# Altium schematic sheet ethernet.SchDoc(ethernet)
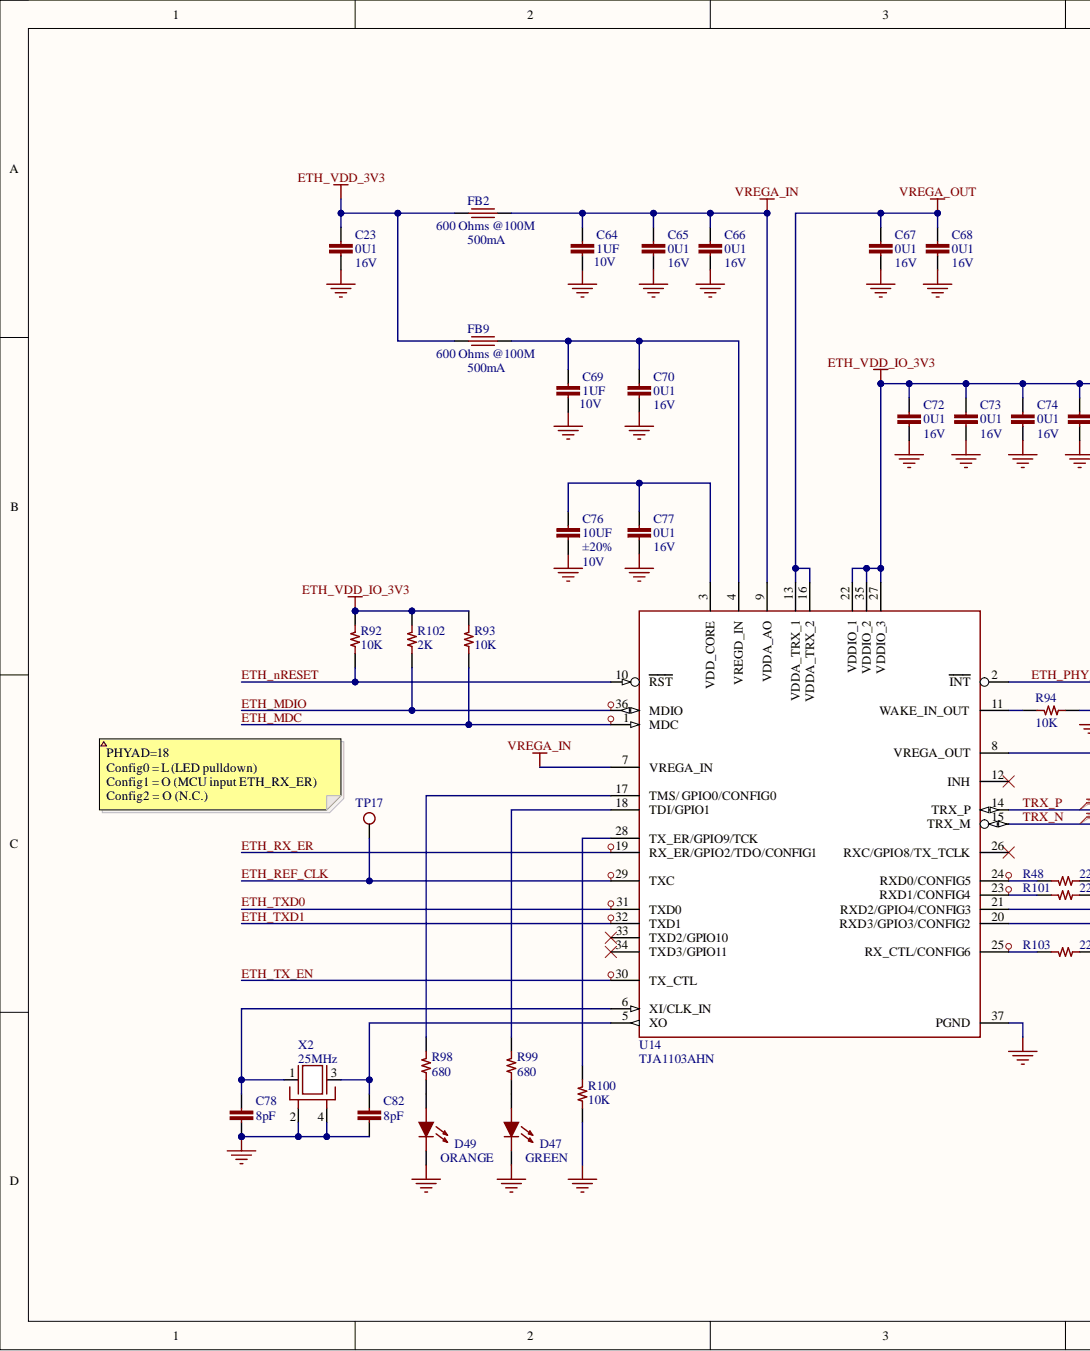
[diagram p0: ethernet.SchDoc, left]
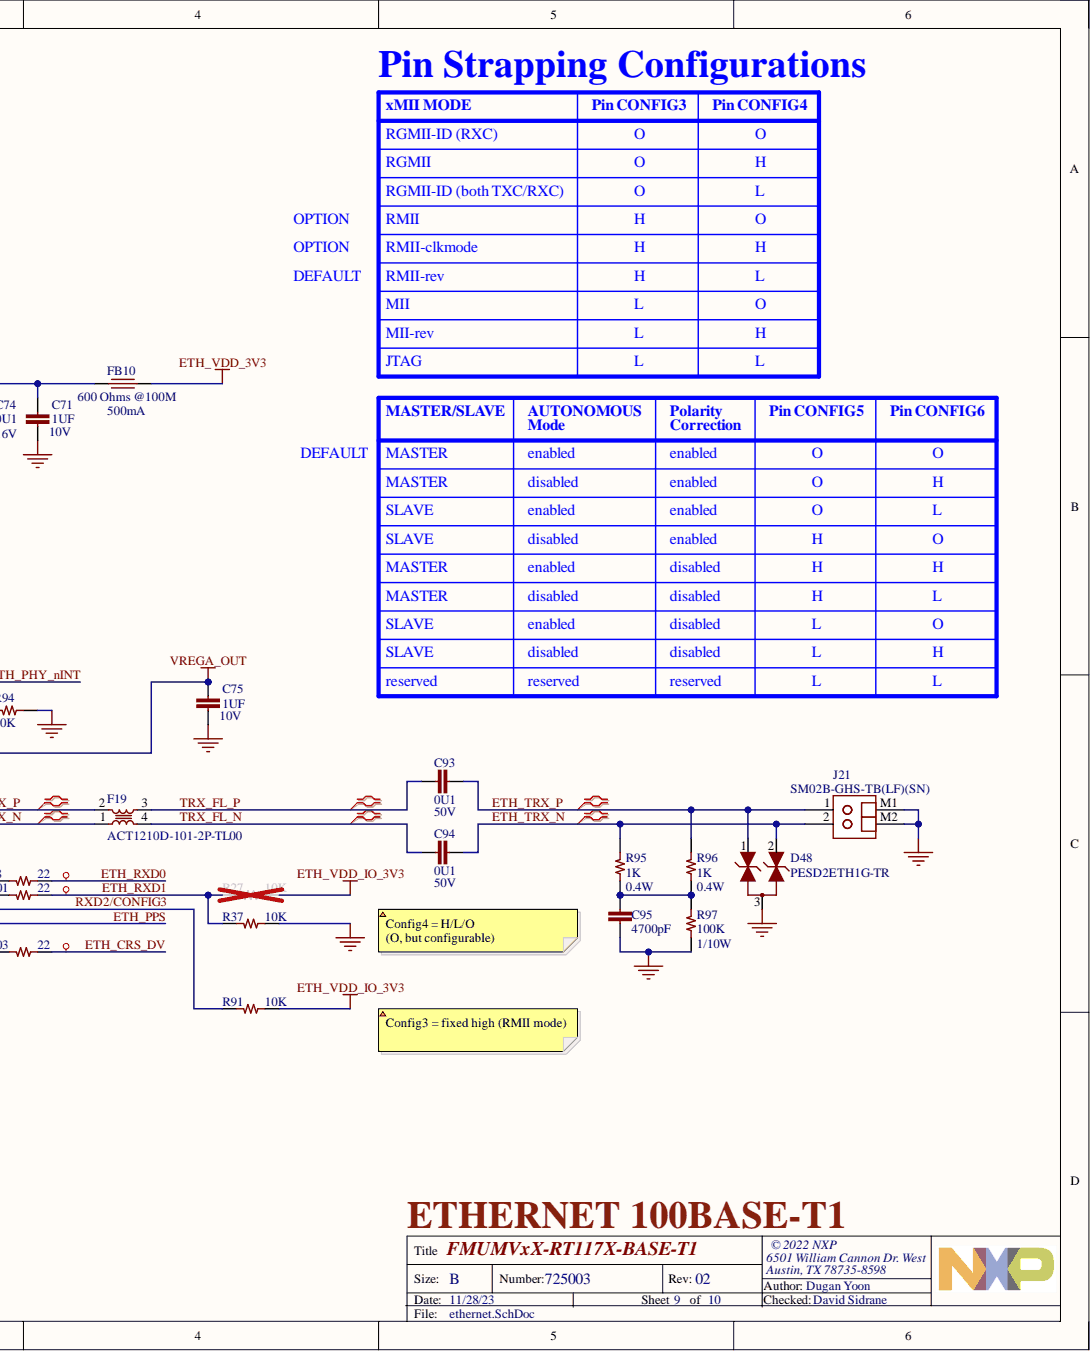
[diagram p1: ethernet.SchDoc, right]

## Parts
C23  value 0U1  Voltage - Rated: 16V  [CAPC100X50X56L25N]  (hidden comment: CAP CER 0.1UF 10% 16V X7R 0402)
C64  value 1UF  Voltage - Rated: 10V  [CAPC100X50X56L25N]  (hidden comment: CAP CER 1UF 20% 10V X5R 0402)
C65  value 0U1  Voltage - Rated: 16V  [CAPC100X50X56L25N]  (hidden comment: CAP CER 0.1UF 10% 16V X7R 0402)
C66  value 0U1  Voltage - Rated: 16V  [CAPC100X50X56L25N]  (hidden comment: CAP CER 0.1UF 10% 16V X7R 0402)
C67  value 0U1  Voltage - Rated: 16V  [CAPC100X50X56L25N]  (hidden comment: CAP CER 0.1UF 10% 16V X7R 0402)
C68  value 0U1  Voltage - Rated: 16V  [CAPC100X50X56L25N]  (hidden comment: CAP CER 0.1UF 10% 16V X7R 0402)
C69  value 1UF  Voltage - Rated: 10V  [CAPC100X50X56L25N]  (hidden comment: CAP CER 1UF 20% 10V X5R 0402)
C70  value 0U1  Voltage - Rated: 16V  [CAPC100X50X56L25N]  (hidden comment: CAP CER 0.1UF 10% 16V X7R 0402)
C71  value 1UF  Voltage - Rated: 10V  [CAPC100X50X56L25N]  (hidden comment: CAP CER 1UF 20% 10V X5R 0402)
C72  value 0U1  Voltage - Rated: 16V  [CAPC100X50X56L25N]  (hidden comment: CAP CER 0.1UF 10% 16V X7R 0402)
C73  value 0U1  Voltage - Rated: 16V  [CAPC100X50X56L25N]  (hidden comment: CAP CER 0.1UF 10% 16V X7R 0402)
C74  value 0U1  Voltage - Rated: 16V  [CAPC100X50X56L25N]  (hidden comment: CAP CER 0.1UF 10% 16V X7R 0402)
C75  value 1UF  Voltage - Rated: 10V  [CAPC100X50X56L25N]  (hidden comment: CAP CER 1UF 20% 10V X5R 0402)
C76  value 10UF  Tolerance: ±20%  Voltage - Rated: 10V  [CAPC100X50X56L25N]  (hidden comment: CAP CER 10UF 20% 10V X5R 0402)
C77  value 0U1  Voltage - Rated: 16V  [CAPC100X50X56L25N]  (hidden comment: CAP CER 0.1UF 10% 16V X7R 0402)
C78  value 8pF  [CAPC100X50X56L25N]  (hidden comment: CAP CER 8PF 50V C0G/NP0 0402)
C82  value 8pF  [CAPC100X50X56L25N]  (hidden comment: CAP CER 8PF 50V C0G/NP0 0402)
C93  value 0U1  [CAPC100X50X56L25N]  (hidden comment: CAP CER 0.1UF 10% 50V X7R 0402)
C94  value 0U1  Voltage - Rated: 50V  [CAPC100X50X56L25N]  (hidden comment: CAP CER 0.1UF 10% 50V X7R 0402)
C95  value 4700pF  [CAPC100X50X56L25N]  (hidden comment: CAP CER 4700PF 10% 50V X7R 0402)
D47  Color: GREEN  [LEDSC80X160X65L40N_GRN]  (hidden comment: LED GREEN CLEAR 0603 SMD)
D48  Manufacturer Part Number: PESD2ETH1G-TR  [SOT23-3P95_230X110L30X43N_GENERIC]  (hidden comment: DIODE TVS DUAL ETHERNET TO-236AB)
D49  Color: ORANGE  [LEDSC80X160X65L40N_ORA]  (hidden comment: LED ORANGE CLEAR 0603 SMD)
F19  Manufacturer Part Number: ACT1210D-101-2P-TL00  [TDK_ACT1210D]  (hidden comment: CMC 100uH 150mA 2LN 5.1KOHMS SMD)
FB2  value 600 Ohms @100M  Current: 500mA  [BEADC160X80X95L36N]  (hidden comment: IND FERRITE 600 OHMS @100M 500mA 0603)
FB9  value 600 Ohms @100M  Current: 500mA  [BEADC160X80X95L36N]  (hidden comment: IND FERRITE 600 OHMS @100M 500mA 0603)
FB10  value 600 Ohms @100M  Current: 500mA  [BEADC160X80X95L36N]  (hidden comment: IND FERRITE 600 OHMS @100M 500mA 0603)
J21  Manufacturer Part Number: SM02B-GHS-TB(LF)(SN)  [JST_SM02B-GHS-TBLFSN]  (hidden comment: CONN HEADER GH SIDE 2POS 1.25MM SMT)
R27  value 10K  [RESC100X50X40L25]  (hidden comment: RES SMD 10K OHM 1% 1/16W 0402)
R37  value 10K  [RESC100X50X40L25]  (hidden comment: RES SMD 10K OHM 1% 1/16W 0402)
R48  value 22  [RESC100X50X40L25]  (hidden comment: RES SMD 22 OHM 1% 1/16W 0402)
R91  value 10K  [RESC100X50X40L25]  (hidden comment: RES SMD 10K OHM 1% 1/16W 0402)
R92  value 10K  [RESC100X50X40L25]  (hidden comment: RES SMD 10K OHM 1% 1/16W 0402)
R93  value 10K  [RESC100X50X40L25]  (hidden comment: RES SMD 10K OHM 1% 1/16W 0402)
R94  value 10K  [RESC100X50X40L25]  (hidden comment: RES SMD 10K OHM 1% 1/16W 0402)
R95  value 1K  Power: 0.4W  [RESC200X125X70L40N]  (hidden comment: RES SMD 1K OHM 1% 0.4W 0805)
R96  value 1K  Power: 0.4W  [RESC200X125X70L40N]  (hidden comment: RES SMD 1K OHM 1% 0.4W 0805)
R97  value 100K  Power: 1/10W  [RESC1608X55L30N]  (hidden comment: RES 100K 1% 1/10W 0603)
R98  value 680  [RESC100X50X40L25]  (hidden comment: RES SMD 680 OHM 1% 1/16W 0402)
R99  value 680  [RESC100X50X40L25]  (hidden comment: RES SMD 680 OHM 1% 1/16W 0402)
R100  value 10K  [RESC100X50X40L25]  (hidden comment: RES SMD 10K OHM 1% 1/16W 0402)
R101  value 22  [RESC100X50X40L25]  (hidden comment: RES SMD 22 OHM 1% 1/16W 0402)
R102  value 2K  [RESC100X50X40L25]  (hidden comment: RES SMD 2K OHM 1% 1/16W 0402)
R103  value 22  [RESC100X50X40L25]  (hidden comment: RES SMD 22 OHM 1% 1/16W 0402)
TP17  TESTPOINT PAD SMD  [TEST_PAD_1MM]  (value not drawn)  (no BOM)
U14  Manufacturer Part Number: TJA1103AHN  [QFN37P50_600X600X85L55X24T390N]  (hidden comment: IC ETHERNET PHY 3.3/2.5/1.1V AEC-Q100 HVQFN36)
X2  Frequency: 25MHz  [OSCCC200X160X70L60X60]  (hidden comment: CRYSTAL 25.0000MHZ 6PF 4-SMD)

## Nets
ETH_VDD_3V3: C23.1 FB2.1 FB9.1 FB10.2
ETH_VDD_IO_3V3: C71.1 C72.1 C73.1 C74.1 FB10.1 R27.2 R91.2 R92.1 R93.1 R102.1 U14.22(VDDIO_1) U14.27(VDDIO_3) U14.35(VDDIO_2)
GND: C23.2 C64.2 C65.2 C66.2 C67.2 C68.2 C69.2 C70.2 C71.2 C72.2 C73.2 C74.2 C75.2 C76.2 C77.2 C78.2 C82.2 C95.2 D47.C D48.3 D49.C J21.M1 J21.M2 R37.2 R94.2 R97.2 R100.2 U14.37(PGND) X2.2 X2.4
VREGA_IN: C64.1 C65.1 C66.1 FB2.2 U14.7(VREGA_IN) U14.9(VDDA_AO)
VREGA_OUT: C67.1 C68.1 C75.1 U14.8(VREGA_OUT) U14.13(VDDA_TRX_1) U14.16(VDDA_TRX_2)
ETH_CRS_DV: R103.2
ETH_MDC: R93.2 U14.1(MDC)
ETH_MDIO: R102.2 U14.36(MDIO)
ETH_nRESET: R92.2 U14.10(RST)
ETH_PHY_nINT: U14.2(INT)
ETH_PPS: U14.20(RXD3/GPIO3/CONFIG2)
ETH_REF_CLK: TP17.1 U14.29(TXC)
ETH_RX_ER: U14.19(RX_ER/GPIO2/TDO/CONFIG1)
ETH_RXD0: R48.2
ETH_RXD1: R27.1 R37.1 R101.2
ETH_TRX_N: C94.2 D48.2 J21.2 R95.1
ETH_TRX_P: C93.2 D48.1 J21.1 R96.1
ETH_TX_EN: U14.30(TX_CTL)
ETH_TXD0: U14.31(TXD0)
ETH_TXD1: U14.32(TXD1)
RXD2/CONFIG3: R91.1 U14.21(RXD2/GPIO4/CONFIG3)
TRX_FL_N: C94.1 F19.4
TRX_FL_P: C93.1 F19.3
TRX_N: F19.1 U14.15(TRX_M)
TRX_P: F19.2 U14.14(TRX_P)
NetC69_1: C69.1 C70.1 FB9.2 U14.4(VREGD_IN)
NetC76_1: C76.1 C77.1 U14.3(VDD_CORE)
NetC78_1: C78.1 U14.6(XI/CLK_IN) X2.1
NetC82_1: C82.1 U14.5(XO) X2.3
NetC95_1: C95.1 R95.2 R96.2 R97.1
NetD47_A: D47.A R99.2
NetD49_A: D49.A R98.2
NetR48_1: R48.1 U14.24(RXD0/CONFIG5)
NetR94_1: R94.1 U14.11(WAKE_IN_OUT)
NetR98_1: R98.1 U14.17(TMS/_GPIO0/CONFIG0)
NetR99_1: R99.1 U14.18(TDI/GPIO1)
NetR100_1: R100.1 U14.28(TX_ER/GPIO9/TCK)
NetR101_1: R101.1 U14.23(RXD1/CONFIG4)
NetR103_1: R103.1 U14.25(RX_CTL/CONFIG6)

Not connected: U14 12(INH) 26(RXC/GPIO8/TX_TCLK) 33(TXD2/GPIO10) 34(TXD3/GPIO11)

## Library data of the parts
C23 C65-C68 C70 C72-C74 C77 = CAP_0U1_16V_X7R_0402: Manufacturer: Murata Electronics; Manufacturer Part Number: GCM155R71C104KA55J; Category: Capacitors; Component Kind: Standard; ComponentLink1Description: https://www.digikey.com/product-detail/en/murata-electronics/GCM155R71C104KA55J/490-7998-1-ND/4380283; ComponentLink1URL: https://www.digikey.com/product-detail/en/murata-electronics/GCM155R71C104KA55J/490-7998-1-ND/4380283; Description: CAP CER 0.1UF 10% 16V X7R 0402; Operating Temperature: -55°C ~ 125°C; Packaging: Cut Tape (CT); Part Status: Active; Pricing 1: 1=0.1, 10=0.049, 100=0.022, 500=0.0157, 1000=0.01233, 2500=0.01121, 5000=0.01032 (USD); Stock 1: 6381653; Supplier 1: Digi-Key; Supplier Part Number 1: 490-7998-1-ND; Tolerance: ±10%; Library Name: NscDG_Integrated_Library.SchLib
C64 C69 C71 C75 = CAP_1UF_20%_10V_X5R_0402: Manufacturer: Yageo; Manufacturer Part Number: CC0402MRX5R6BB105; Category: Capacitors; Component Kind: Standard; ComponentLink1Description: https://www.digikey.com/product-detail/en/yageo/CC0402MRX5R6BB105/311-1732-1-ND/5195634; ComponentLink1URL: https://www.digikey.com/product-detail/en/yageo/CC0402MRX5R6BB105/311-1732-1-ND/5195634; Description: CAP CER 1UF 20% 10V X5R 0402; Operating Temperature: -55°C ~ 85°C; Packaging: Tape & Reel (TR); Part Status: Active; Pricing 1: 1=0.22, 10=0.156, 100=0.0732, 10000=0.02925 (USD); Ratings: -; Stock 1: 52516; Supplier 1: Digi-Key; Supplier Part Number 1: 311-1732-1-ND; Temperature Coefficient: X5R; Tolerance: ±20%; Library Name: NscDG_Integrated_Library.SchLib
C76 = CAP_10UF_20%_10V_X5R_0402: Manufacturer: Samsung Electro-Mechanics; Manufacturer Part Number: CL05A106MP5NUNC; Category: Capacitors; Component Kind: Standard; ComponentLink1Description: https://www.digikey.com/products/en?keywords=%091276-1450-1-ND; ComponentLink1URL: https://www.digikey.com/products/en?keywords=%091276-1450-1-ND; Description: CAP CER 10UF 20% 10V X5R 0402; Operating Temperature: -55°C ~ 85°C; Packaging: Tape & Reel (TR); Part Status: Active; Pricing 1: 1=0.53, 10=0.373, 100=0.23, 500=0.1761, 1000=0.15094, 2500=0.14375, 5000=0.13656 (USD); Ratings: -; Stock 1: 0; Supplier 1: Digi-Key; Supplier Part Number 1: 1276-1450-1-ND; Temperature Coefficient: X5R; Library Name: NscDG_Integrated_Library.SchLib
C78 C82 = CAP_8PF_50V_NPO_0402: Manufacturer: Yageo; Manufacturer Part Number: CC0402DRNPO9BN8R0; Temperature Coefficient: C0G, NP0; Tolerance: ±0.5pF; Category: Capacitors; Component Kind: Standard; ComponentLink1Description: https://www.digikey.com/product-detail/en/yageo/CC0402DRNPO9BN8R0/311-1639-1-ND/5195541; ComponentLink1URL: https://www.digikey.com/product-detail/en/yageo/CC0402DRNPO9BN8R0/311-1639-1-ND/5195541; Description: CAP CER 8PF 50V C0G/NP0 0402; Operating Temperature: -55°C ~ 125°C; Packaging: Cut Tape (CT); Part Status: Active; Pricing 1: 1=0.1, 10=0.032, 100=0.0143, 500=0.0102, 1000=0.00802, 2500=0.00729, 5000=0.0067 (USD); Stock 1: 455831; Supplier 1: Digi-Key; Supplier Part Number 1: 311-1639-1-ND; Voltage - Rated: 50V; Library Name: NscDG_Integrated_Library.SchLib
C93 C94 = CAP_0U1_50V_X7R_0402: Manufacturer: TDK Corporation; Manufacturer Part Number: C1005X7R1H104K050BB; Category: Capacitors; Component Kind: Standard; ComponentLink1Description: https://www.digikey.com/en/products/detail/tdk-corporation/C1005X7R1H104K050BB/2443410; ComponentLink1URL: https://www.digikey.com/en/products/detail/tdk-corporation/C1005X7R1H104K050BB/2443410; Description: CAP CER 0.1UF 10% 50V X7R 0402; Operating Temperature: -55°C ~ 125°C; Packaging: Cut Tape (CT); Part Status: Active; Pricing 1: 1=0.12 (USD); Stock 1: 4733244; Supplier 1: Digi-Key; Supplier Part Number 1: 445-5932-1-ND; Tolerance: ±10%; Library Name: NscDG_Integrated_Library.SchLib
  C93: Voltage - Rated: 50V
C95 = CAP_4700PF_5%_50V_X7R_0402: Manufacturer: Murata Electronics; Manufacturer Part Number: GRM155R71H472KA01J; Category: Capacitors; Component Kind: Standard; ComponentLink1Description: https://www.digikey.com/en/products/detail/murata-electronics/GRM155R71H472KA01J/2610945; ComponentLink1URL: https://www.digikey.com/en/products/detail/murata-electronics/GRM155R71H472KA01J/2610945; Description: CAP CER 4700PF 10% 50V X7R 0402; Operating Temperature: -55°C ~ 125°C; Packaging: Cut Tape (CT); Part Status: Active; Pricing 1: 1=0.1, 10=0.011, 100=0.005, 500=0.00354, 1000=0.00278, 2500=0.00253, 5000=0.00233 (USD); Ratings: -; Stock 1: 457897; Supplier 1: Digi-Key; Supplier Part Number 1: 490-8259-1-ND; Temperature Coefficient: X7R; Tolerance: ±10%; Voltage - Rated: 50V; Library Name: NscDG_Integrated_Library.SchLib
D47 = DIODE_LED_GREEN_0603: Manufacturer: Lite-On Inc.; Manufacturer Part Number: LTST-C191KGKT; Category: Optoelectronics; Component Kind: Standard; ComponentLink1Description: http://optoelectronics.liteon.com/upload/download/DS22-2000-228/LTST-C191KGKT.PDF; ComponentLink1URL: http://optoelectronics.liteon.com/upload/download/DS22-2000-228/LTST-C191KGKT.PDF; ComponentLink2Description: https://www.digikey.com/product-detail/en/lite-on-inc/LTST-C191KGKT/160-1446-1-ND/386834; ComponentLink2URL: https://www.digikey.com/product-detail/en/lite-on-inc/LTST-C191KGKT/160-1446-1-ND/386834; Description: LED GREEN CLEAR 0603 SMD; Packaging: Cut Tape (CT); Part Status: Active; Pricing 1: 1=0.34, 10=0.253, 25=0.1872, 100=0.1353 (USD); Stock 1: 2166001; Supplier 1: Digi-Key; Supplier Part Number 1: 160-1446-1-ND; Library Name: NscDG_Integrated_Library.SchLib
D48 = DIODE_TVS_DUAL_ETHERNET_TO236AB: Manufacturer: Nexperia USA Inc.; Category: Discrete Semiconductor Products; Component Kind: Standard; ComponentLink1Description: https://www.digikey.com/en/products/detail/nexperia-usa-inc/PESD2ETH1G-TR/11487055?s=N4IgTCBcDaIA4FMDOATMCAuALAjAcwFoMQBdAXyA; ComponentLink1URL: https://www.digikey.com/en/products/detail/nexperia-usa-inc/PESD2ETH1G-TR/11487055?s=N4IgTCBcDaIA4FMDOATMCAuALAjAcwFoMQBdAXyA; Description: DIODE TVS DUAL ETHERNET TO-236AB; Packaging: Cut Tape (CT); Part Status: Active; Pricing 1: 1=0.40 (USD); Stock 1: 0; Supplier 1: Digi-Key; Supplier Part Number 1: 1727-PESD2ETH1G-CT-ND; Library Name: NscDG_Integrated_Library.SchLib
D49 = DIODE_LED_ORANGE_0603: Manufacturer: Lite-On Inc.; Manufacturer Part Number: LTST-C191KFKT; Category: Optoelectronics; Component Kind: Standard; ComponentLink1Description: http://optoelectronics.liteon.com/upload/download/DS22-2000-228/LTST-C191KGKT.PDF; ComponentLink1URL: http://optoelectronics.liteon.com/upload/download/DS22-2000-228/LTST-C191KGKT.PDF; ComponentLink2Description: https://www.digikey.com/product-detail/en/lite-on-inc/LTST-C191KFKT/160-1445-1-ND/386832; ComponentLink2URL: https://www.digikey.com/product-detail/en/lite-on-inc/LTST-C191KFKT/160-1445-1-ND/386832; Description: LED ORANGE CLEAR 0603 SMD; Packaging: Cut Tape (CT); Part Status: Active; Pricing 1: 1=0.34, 10=0.253, 25=0.1872, 100=0.1353 (USD); Stock 1: 146932; Supplier 1: Digi-Key; Supplier Part Number 1: 160-1445-1-ND; Library Name: NscDG_Integrated_Library.SchLib
F19 = FILTER_CMC_100uH_150mA_2LN_SMD: Manufacturer: TDK Corporation; Voltage - Rated: 5V; Category: Filters; Component Kind: Standard; ComponentLink1Description: https://www.digikey.com/en/products/detail/tdk-corporation/act1210d-101-2p-tl00/13672016; ComponentLink1URL: https://www.digikey.com/en/products/detail/tdk-corporation/act1210d-101-2p-tl00/13672016; Current - Rated: 150mA; Description: CMC 100uH 150mA 2LN 5.1KOHMS SMD; Operating Temperature: -55°C ~ 150°C; Packaging: Cut Tape (CT); Part Status: Active; Pricing 1: 1=1.88 (USD); Stock 1: 9046; Supplier 1: Digi-Key; Supplier Part Number 1: 445-ACT1210D-101-2P-TL00CT-ND; Library Name: NscDG_Integrated_Library.SchLib
FB2 FB9 FB10 = IND_FERRITE_600OHM@100M_500mA_0603: Manufacturer: Murata Electronics North America; Manufacturer Part Number: BLM18AG601BH1D; Category: Inductors; Component Kind: Standard; ComponentLink1Description: https://www.digikey.com/en/products/detail/murata-electronics/BLM18AG601BH1D/6156010?s=N4IgTCBcDaIEYBsC2BGAHAQwOYDYAMKcAFigCYgC6AvkA; ComponentLink1URL: https://www.digikey.com/en/products/detail/murata-electronics/BLM18AG601BH1D/6156010?s=N4IgTCBcDaIEYBsC2BGAHAQwOYDYAMKcAFigCYgC6AvkA; Description: IND FERRITE 600 OHMS @100M 500mA 0603; Operating Temperature: -55°C ~ 150°C; Packaging: Cut Tape (CT); Part Status: Active; Pricing 1: 1=0.12 (USD); Stock 1: 39046; Supplier 1: Digi-Key; Supplier Part Number 1: 490-7809-1-ND; Library Name: NscDG_Integrated_Library.SchLib
J21 = CONN_JST_GH_2PIN_RA_SMT: Manufacturer: JST Sales America Inc.; System: Connector; Voltage - Rated: 50V; Category: Connectors, Interconnects; Component Kind: Standard; ComponentLink1Description: https://www.digikey.com/products/en/connectors-interconnects/rectangular-connectors-headers-male-pins/314?k=sm02b&k=&pkeyword=sm02b&sv=0&pv7=2&s=2395&pv1989=0&sf=0&FV=ffe0013a&quantity=&ColumnSort=0&page=1&pageSize=25; ComponentLink1URL: https://www.digikey.com/products/en/connectors-interconnects/rectangular-connectors-headers-male-pins/314?k=sm02b&k=&pkeyword=sm02b&sv=0&pv7=2&s=2395&pv1989=0&sf=0&FV=ffe0013a&quantity=&ColumnSort=0&page=1&pageSize=25; Description: CONN HEADER GH SIDE 2POS 1.25MM SMT; Operating Temperature: -25°C ~ 85°C; Packaging: Cut Tape (CT); Part Status: Active; Pricing 1: 1=0.44, 10=0.413, 100=0.316, 500=0.27502, 1000=0.22689 (USD); Stock 1: 90823; Supplier 1: Digi-Key; Supplier Part Number 1: 455-1564-1-ND; Library Name: NscDG_Integrated_Library.SchLib
R27 R37 R91-R94 R100 = RES_10K_1%_1/16W_0402: Manufacturer: Vishay Dale; Manufacturer Part Number: CRCW040210K0FKED; Category: Resistors; Component Kind: Standard; ComponentLink1Description: https://www.digikey.com/products/en?keywords=311-10.0KLRCT-ND; ComponentLink1URL: https://www.digikey.com/products/en?keywords=311-10.0KLRCT-ND; Description: RES SMD 10K OHM 1% 1/16W 0402; Operating Temperature: -55°C ~ 155°C; Packaging: Cut Tape (CT); Part Status: Active; Power: 1/16W; Pricing 1: 1=0.1, 10=0.014, 100=0.0056, 1000=0.00253, 2500=0.0022, 5000=0.00181 (USD); Stock 1: 37996194; Supplier 1: Digi-Key; Supplier Part Number 1: 541-10.0KLCT-ND; Tolerance: ±1%; Library Name: NscDG_Integrated_Library.SchLib
R48 R101 R103 = RES_22R_1%_1/16W_0402: Manufacturer: Yageo; Manufacturer Part Number: RC0402FR-0722RL; Category: Resistors; Component Kind: Standard; ComponentLink1Description: https://www.digikey.com/product-detail/en/yageo/RC0402FR-0722RL/311-22.0LRCT-ND/729509; ComponentLink1URL: https://www.digikey.com/product-detail/en/yageo/RC0402FR-0722RL/311-22.0LRCT-ND/729509; Description: RES SMD 22 OHM 1% 1/16W 0402; Operating Temperature: -55°C ~ 155°C; Packaging: Cut Tape (CT); Part Status: Active; Power: 1/16W; Pricing 1: 1=0.1, 10=0.014, 100=0.0056, 1000=0.00253, 2500=0.0022, 5000=0.00181 (USD); Stock 1: 24406416; Supplier 1: Digi-Key; Supplier Part Number 1: 311-22.0LRCT-ND; Tolerance: ±1%; Library Name: NscDG_Integrated_Library.SchLib
R95 R96 = RES_1K_1%_0.4W_0805: Manufacturer: Rohm Semiconductor; Manufacturer Part Number: ESR10EZPF1001; Category: Resistors; Component Kind: Standard; ComponentLink1Description: https://www.digikey.com/en/products/detail/rohm-semiconductor/ESR10EZPF1001/1983480; ComponentLink1URL: https://www.digikey.com/en/products/detail/rohm-semiconductor/ESR10EZPF1001/1983480; Description: RES SMD 1K OHM 1% 0.4W 0805; Operating Temperature: -55°C ~ 155°C; Packaging: Cut Tape (CT); Part Status: Active; Pricing 1: 1=0.18 (USD); Stock 1: 368234; Supplier 1: Digi-Key; Supplier Part Number 1: RHM1.00KAECT-ND; Tolerance: ±1%; Library Name: NscDG_Integrated_Library.SchLib
R97 = RES_100K_1%_1/10W_0603: Manufacturer: Yageo; Manufacturer Part Number: RC0603FR-07100KL; Category: Resistors; Component Kind: Standard; ComponentLink1Description: http://www.yageo.com/documents/recent/PYu-RC_Group_51_RoHS_L_7.pdf; ComponentLink1URL: http://www.yageo.com/documents/recent/PYu-RC_Group_51_RoHS_L_7.pdf; Description: RES 100K 1% 1/10W 0603; Operating Temperature: -55°C ~ 155°C; Packaging: Digi-Reel®; Part Status: Active; Pricing 1: 1=0.1, 10=0.015, 100=0.006, 1000=0.00268, 2500=0.00233 (USD); Stock 1: 10050286; Supplier 1: Digi-Key; Supplier Part Number 1: 311-100KHRDKR-ND; Tolerance: ±1%; Library Name: NscDG_Integrated_Library.SchLib
R98 R99 = RES_680_1%_1/16W_0402: Manufacturer: Yageo; Manufacturer Part Number: AC0402FR-07680RL; Category: Resistors; Component Kind: Standard; ComponentLink1Description: https://www.digikey.com/en/products/detail/yageo/AC0402FR-07680RL/5895472; ComponentLink1URL: https://www.digikey.com/en/products/detail/yageo/AC0402FR-07680RL/5895472; Description: RES SMD 680 OHM 1% 1/16W 0402; Operating Temperature: -55°C ~ 155°C; Packaging: Cut Tape (CT); Part Status: Active; Power: 1/16W; Pricing 1: 1=0.1, 10=0.014, 100=0.0056, 1000=0.00253, 2500=0.0022, 5000=0.00181 (USD); Stock 1: 97012; Supplier 1: Digi-Key; Supplier Part Number 1: YAG3505CT-ND; Tolerance: ±1%; Library Name: NscDG_Integrated_Library.SchLib
R102 = RES_2K_1%_1/16W_0402: Manufacturer: Yageo; Manufacturer Part Number: RC0402FR-072KL; Category: Resistors; Component Kind: Standard; ComponentLink1Description: https://www.digikey.com/product-detail/en/yageo/RC0402FR-072KL/311-2KLRCT-ND/2827883; ComponentLink1URL: https://www.digikey.com/product-detail/en/yageo/RC0402FR-072KL/311-2KLRCT-ND/2827883; Description: RES SMD 2K OHM 1% 1/16W 0402; Operating Temperature: -55°C ~ 155°C; Packaging: Cut Tape (CT); Part Status: Active; Power: 1/16W; Pricing 1: 1=0.1, 10=0.014, 100=0.0056, 1000=0.00253, 2500=0.0022, 5000=0.00181 (USD); Stock 1: 18685853; Supplier 1: Digi-Key; Supplier Part Number 1: 311-2KLRCT-ND; Tolerance: ±1%; Library Name: NscDG_Integrated_Library.SchLib
TP17 = TESTPOINT_PAD_SMD: CATEGORY: Test and Measurement; Description: TESTPOINT PAD SMD; Manufacturer: N/A; Library Name: NscDG_Integrated_Library.SchLib
U14 = IC_TJA1103A_ETHERNET_HVQFN36: Manufacturer: NXP SEMICONDUCTORS; Category: Integrated Circuits (ICs); Component Kind: Standard; ComponentLink1Description: https://www.digikey.com/en/products/detail/nxp-usa-inc/TJA1103AHN-0Z/17128756?s=N4IgTCBcDaICoCkCCBGFAGAzEgEgORAF0BfIA; ComponentLink1URL: https://www.digikey.com/en/products/detail/nxp-usa-inc/TJA1103AHN-0Z/17128756?s=N4IgTCBcDaICoCkCCBGFAGAzEgEgORAF0BfIA; Description: IC ETHERNET PHY 3.3/2.5/1.1V AEC-Q100 HVQFN36; Operating Temperature: -40°C ~ 125°C; Packaging: Cut Tape (CT); Part Status: Active; Pricing 1: 1=TBD (USD); Stock 1: 0; Supplier 1: Digi-Key; Supplier Part Number 1: 568-TJA1103AHN/0ZCT-ND; Library Name: NscDG_Integrated_Library.SchLib
X2 = XTAL_25.0000MHZ_6PF_4-SMD: Manufacturer: Murata Electronics; Manufacturer Part Number: XRCGB25M000F3A00R0; Frequency Stability: ±35ppm; Category: Crystals, Oscillators, Resonators; Component Kind: Standard; ComponentLink1Description: https://www.digikey.com/en/products/detail/murata-electronics/XRCGB25M000F3A00R0/5403569?s=N4IgTCBcDaIB4CcDGBzARmArAWwAz4DMBmAQ3wVxAF0BfIA; ComponentLink1URL: https://www.digikey.com/en/products/detail/murata-electronics/XRCGB25M000F3A00R0/5403569?s=N4IgTCBcDaIB4CcDGBzARmArAWwAz4DMBmAQ3wVxAF0BfIA; Description: CRYSTAL 25.0000MHZ 6PF 4-SMD; Frequency Tolerance: ±35ppm; Load Capacitance: 6pF; Operating Temperature: -40°C ~ 125°C; Packaging: Cut Tape (CT); Part Status: Active; Pricing 1: 1=0.55 (USD); Stock 1: 4510; Supplier 1: Digi-Key; Supplier Part Number 1: 490-12146-1-ND; Library Name: NscDG_Integrated_Library.SchLib
